# Altium schematic sheet [14] - CLOCK I.SchDoc("[14] - CLOCK I")
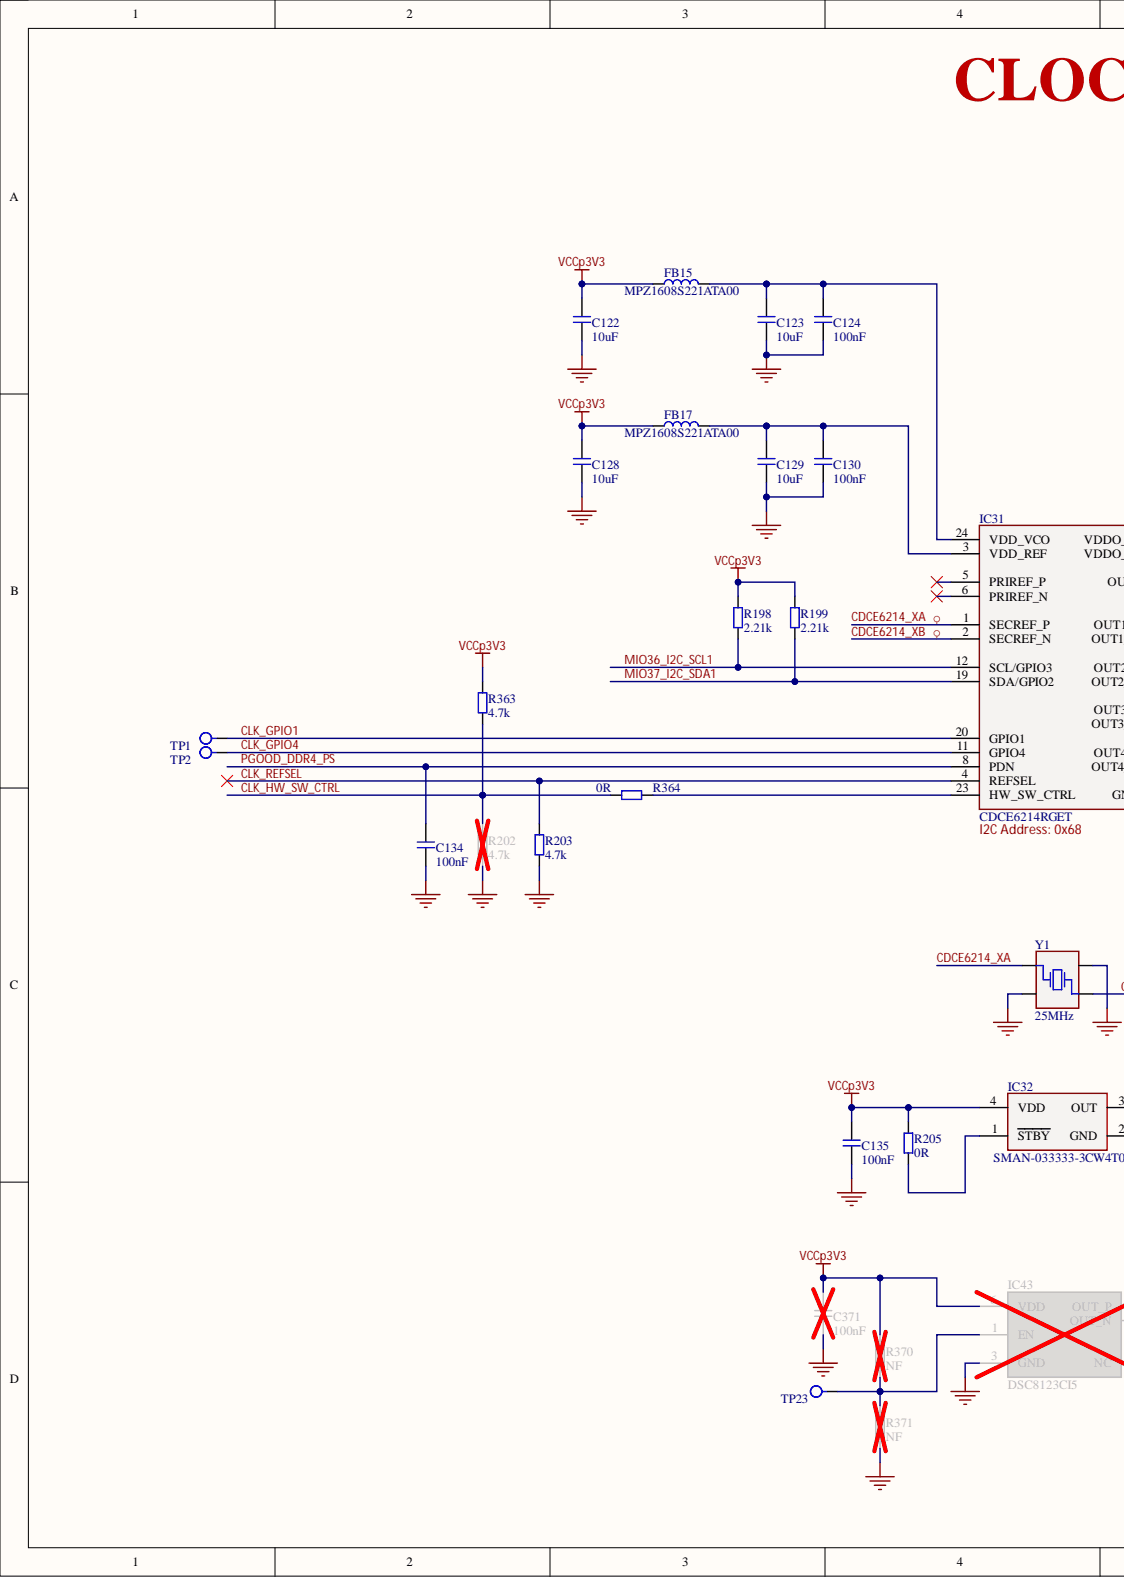
[diagram p0: [14] - CLOCK I.SchDoc, left]
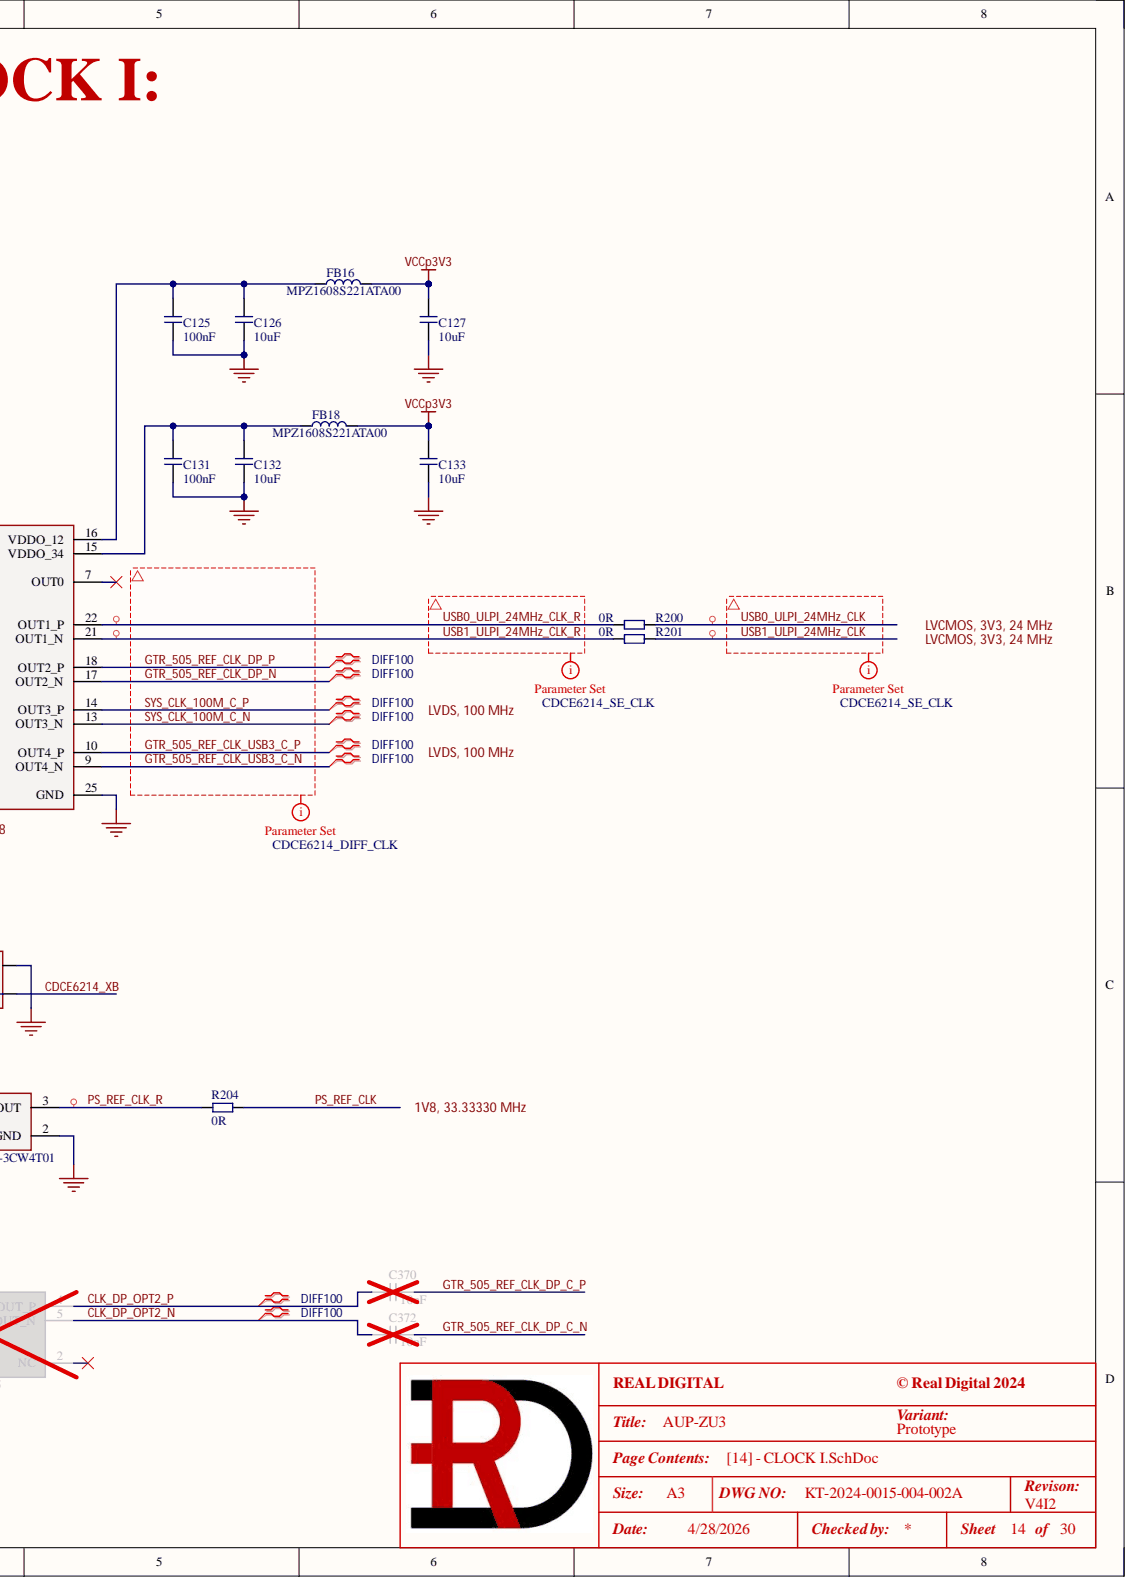
[diagram p1: [14] - CLOCK I.SchDoc, right]

## Parts
C122  10uF  [C0402]
C123  10uF  [C0402]
C124  100nF  [C0201]
C125  100nF  [C0201]
C126  10uF  [C0402]
C127  10uF  [C0402]
C128  10uF  [C0402]
C129  10uF  [C0402]
C130  100nF  [C0201]
C131  100nF  [C0201]
C132  10uF  [C0402]
C133  10uF  [C0402]
C134  100nF  [C0402]
C135  100nF  [C0402]
C370  10nF  [C0402]
C371  100nF  [C0201]
C372  10nF  [C0402]
FB15  MPZ1608S221ATA00  [MPZ1608]
FB16  MPZ1608S221ATA00  [MPZ1608]
FB17  MPZ1608S221ATA00  [MPZ1608]
FB18  MPZ1608S221ATA00  [MPZ1608]
IC31  CDCE6214RGET  [RGE0024P_VQFN24 - TI]
IC32  SMAN-033333-3CW4T01  [SMAN-033333-3CW4T01]
IC43  DSC8123CI5  [DSC8123C - MCH]
R198  2.21k  [R0402]
R199  2.21k  [R0402]
R200  0R  [R0201]
R201  0R  [R0201]
R202  4.7k  [R0402]
R203  4.7k  [R0402]
R204  0R  [R0402]
R205  0R  [R0402]
R363  4.7k  [R0402]
R364  0R  [R0402]
R370  NF  [R0402]
R371  NF  [R0402]
TP1  1mm  [TP_1MM]  (value not drawn)  (no BOM)
TP2  1mm  [TP_1MM]  (value not drawn)  (no BOM)
TP23  1mm  [TP_1MM]  (value not drawn)  (no BOM)
Y1  25MHz  [Y_FA-238]

## Nets
GND: C122.1 C123.1 C124.1 C125.1 C126.1 C127.1 C128.1 C129.1 C130.1 C131.1 C132.1 C133.1 C134.1 C135.1 C371.1 IC31.25(GND) IC32.2(GND) IC43.3(GND) R202.1 R203.1 R371.1 Y1.2 Y1.4
VCCp3V3: C122.2 C127.2 C128.2 C133.2 C135.2 C371.2 FB15.1 FB16.2 FB17.1 FB18.2 IC32.4(VDD) IC43.6(VDD) R198.2 R199.2 R205.2 R363.2 R370.2
CDCE6214_XA: IC31.1(SECREF_P) Y1.1
CDCE6214_XB: IC31.2(SECREF_N) Y1.3
CLK_DP_OPT2_N: C372.1 IC43.5(OUT_N)
CLK_DP_OPT2_P: C370.1 IC43.4(OUT_P)
CLK_GPIO1: IC31.20(GPIO1) TP1.1
CLK_GPIO4: IC31.11(GPIO4) TP2.1
CLK_HW_SW_CTRL: R202.2 R363.1 R364.1
CLK_REFSEL: IC31.4(REFSEL) R203.2
GTR_505_REF_CLK_DP_C_N: C372.2
GTR_505_REF_CLK_DP_C_P: C370.2
GTR_505_REF_CLK_DP_N: IC31.17(OUT2_N)
GTR_505_REF_CLK_DP_P: IC31.18(OUT2_P)
GTR_505_REF_CLK_USB3_C_N: IC31.9(OUT4_N)
GTR_505_REF_CLK_USB3_C_P: IC31.10(OUT4_P)
MIO36_I2C_SCL1: IC31.12(SCL/GPIO3) R198.1
MIO37_I2C_SDA1: IC31.19(SDA/GPIO2) R199.1
PGOOD_DDR4_PS: C134.2 IC31.8(PDN)
PS_REF_CLK: R204.1
PS_REF_CLK_R: IC32.3(OUT) R204.2
SYS_CLK_100M_C_N: IC31.13(OUT3_N)
SYS_CLK_100M_C_P: IC31.14(OUT3_P)
USB0_ULPI_24MHz_CLK: R200.2
USB0_ULPI_24MHz_CLK_R: IC31.22(OUT1_P) R200.1
USB1_ULPI_24MHz_CLK: R201.2
USB1_ULPI_24MHz_CLK_R: IC31.21(OUT1_N) R201.1
NetC123_2: C123.2 C124.2 FB15.2 IC31.24(VDD_VCO)
NetC125_2: C125.2 C126.2 FB16.1 IC31.16(VDDO_12)
NetC129_2: C129.2 C130.2 FB17.2 IC31.3(VDD_REF)
NetC131_2: C131.2 C132.2 FB18.1 IC31.15(VDDO_34)
NetIC31_23: IC31.23(HW_SW_CTRL) R364.2
NetIC32_1: IC32.1(STBY) R205.1
NetIC43_1: IC43.1(EN) R370.1 R371.2 TP23.1

Not connected: IC31 5(PRIREF_P) 6(PRIREF_N) 7(OUT0); IC43 2(NC)

## Library data of the parts
C122 C123 C126-C129 C132 C133 = GRM155R61A106ME11D: CAP CER 10uF 10V X5R 0402; Manufacturer 1: Murata Electronics; .Checked_PCB: RV; C P/N: RD-111-0065; LoadStatus: Load; Tolerance: 20%; Category: Capacitors; Component Kind: Standard; Library Name: KT-RealDigital.IntLib; Manufacturer 1 Part Number: GRM155R61A106ME11D; Temperature Coefficient: X5R; Voltage - Rated: 10V; .Checked_SCH: RV; .Creator: RV
C124 C125 C130 C131 C371 = GRM033C71C104KE14D: CAP CER 0.1uF 16V X7S 0201; Manufacturer 1: Murata Electronics; .Checked_PCB: RV; C P/N: 111-0800; LoadStatus: Load; Tolerance: 10%; Category: Capacitors; Component Kind: Standard; Library Name: KT-RealDigital.IntLib; Manufacturer 1 Part Number: GRM033C71C104KE14D; Temperature Coefficient: X7S; Voltage - Rated: 16V; .Checked_SCH: RV; .Creator: RV
C134 = GRM155R71H104ME14J: CAP CER 0.1uF 50V X7R 0402; Manufacturer 1: Murata Electronics; .Checked_PCB: DG; .Creator: RV; C P/N: RD-111-0069; LoadStatus: Load; Tolerance: 20%; Category: Capacitors; Component Kind: Standard; Library Name: KT-RealDigital.IntLib; Manufacturer 1 Part Number: GRM155R71H104ME14J; Temperature Coefficient: X7R; Voltage - Rated: 50V; .Checked_SCH: DG
C135 = GRM155R71E104KE14J: CAP CER 0.1uF 25V X7R 0402; Manufacturer 1: Murata Electronics; .Checked_PCB: AC; .Creator: RV; C P/N: RD-111-0006; LoadStatus: Load; Tolerance: 10%; Category: Capacitors; Component Kind: Standard; Library Name: KT-RealDigital.IntLib; Manufacturer 1 Part Number: GRM155R71E104KE14J; Temperature Coefficient: X7R; Voltage - Rated: 25V; .Checked_SCH: AC
C370 C372 = GRM155R71H103KA88J: CAP CER 10000pF 50V X7R 0402; Manufacturer 1: Murata Electronics; .Checked_PCB: AC; .Creator: RV; C P/N: RD-111-0003; LoadStatus: Load; Tolerance: 10%; Category: Capacitors; Component Kind: Standard; Library Name: KT-RealDigital.IntLib; Manufacturer 1 Part Number: GRM155R71H103KA88J; Temperature Coefficient: X7R; Voltage - Rated: 50V; .Checked_SCH: AC
FB15-FB18 = MPZ1608S221ATA00: FERRITE BEAD 220 OHM 0603 1LN; Manufacturer 1: TDK Corporation; .Checked_PCB: AC; .Checked_SCH: RV; C P/N: CY-121-0068; LoadStatus: Load; Category: Ferrite Beads; Component Kind: Standard; Library Name: KT-RealDigital.IntLib; Manufacturer 1 Part Number: MPZ1608S221ATA00; .Creator: RV
IC31 = CDCE6214RGET: Clock Generator IC 350MHz 1 24-VFQFN Exposed Pad; Manufacturer 1: Texas Instruments; .Checked_PCB: RV; .Creator: DG; C P/N: RD-126-1527; LoadStatus: Load; Category: IC; Component Kind: Standard; Library Name: KT-RealDigital.IntLib; Manufacturer 1 Part Number: CDCE6214RGET; .Checked_SCH: RV
IC32 = SMAN-033333-3CW4T01: 33.333330MHz XO (Standard) CMOS Oscillator 1.62V ~ 3.63V Standby (Power Down) 4-SMD, No Lead; Manufacturer 1: AKER TECHNOLOGY CO., LTD.; .Checked_PCB: RV; C P/N: EP-126-0228; LoadStatus: Load; Category: Oscillators; Component Kind: Standard; Library Name: KT-RealDigital.IntLib; Manufacturer 1 Part Number: SMAN-033333-3CW4T01; .Checked_SCH: RV; .Creator: RV
IC43 = DSC8123CI5: MEMS OSC PROG BLANK 10MHZ-460MHZ; Manufacturer 1: Microchip Technology; .Checked_PCB: RV; C P/N: RD-126-1530; LoadStatus: Load; Category: IC; Component Kind: Standard; Library Name: KT-RealDigital.IntLib; Manufacturer 1 Part Number: DSC8123CI5; .Checked_SCH: RV; .Creator: RV
R198 R199 = RC0402FR-072K21L: RES SMD 2.21K OHM 1% 1/16W 0402; Manufacturer 1: YAGEO; .Checked_PCB: RV; C P/N: RD-101-0019; LoadStatus: Load; Tolerance: 1%/TK100; Category: Resistors; Component Kind: Standard; Library Name: KT-RealDigital.IntLib; Manufacturer 1 Part Number: RC0402FR-072K21L; .Checked_SCH: RV; .Creator: RV
R200 R201 = RC0201FR-070RL: RES SMD 0 OHM JUMPER 1/20W 0201; Manufacturer 1: YAGEO; .Checked_PCB: RV; C P/N: RD-101-0039; LoadStatus: Load; Category: Resistors; Component Kind: Standard; Library Name: KT-RealDigital.IntLib; Manufacturer 1 Part Number: RC0201FR-070RL; Tolerance: JUMPER; .Checked_SCH: RV; .Creator: RV
R202 R203 R363 = RC0402FR-074K7P: RES SMD 4.7K OHM 1% 1/16W 0402; Manufacturer 1: Yageo; .Checked_PCB: AC; .Creator: RV; C P/N: 101-0129; LoadStatus: Load; Tolerance: 1%/TK100; Category: Resistors; Component Kind: Standard; Library Name: KT-RealDigital.IntLib; Manufacturer 1 Part Number: RC0402FR-074K7P; .Checked_SCH: AC
R204 R205 R364 = RC0402FR-070RL: RES SMD 0 OHM JUMPER 1/16W 0402; Manufacturer 1: YAGEO; .Checked_PCB: AC; .Creator: RV; C P/N: RD-101-0003; LoadStatus: Load; Category: Resistors; Component Kind: Standard; Library Name: KT-RealDigital.IntLib; Manufacturer 1 Part Number: RC0402FR-070RL; Tolerance: Jumper; .Checked_SCH: AC
R370 R371 = !RES0402: Generic Resistor, 0402; .Creator: RV; LoadStatus: Load; Category: Resistors; Component Kind: Standard; Library Name: KT-RealDigital.IntLib
TP1 TP2 TP23 = TEST_POINT_1mm: TEST POINT round 1mm; Manufacturer 1: NA; .Checked_PCB: RV; LoadStatus: Load; Category: Test Point; Library Name: KT-RealDigital.IntLib; Manufacturer 1 Part Number: NA; Manufacturer 2: NA; Manufacturer 2 Part Number: NA; .Checked_SCH: RV; .Creator: RV
Y1 = FA-238 25.0000MD-AJ0: CRYSTAL 25.0000MHz 8PF SMD; Manufacturer 1: EPSON; .Checked_PCB: DG; .Creator: RV; C P/N: RD-129-0030; LoadStatus: Load; Category: Crystals; Component Kind: Standard; Library Name: KT-RealDigital.IntLib; Manufacturer 1 Part Number: FA-238 25.0000MD-AJ0; .Checked_SCH: DG
